# Altium schematic sheet Power_Supply.SchDoc
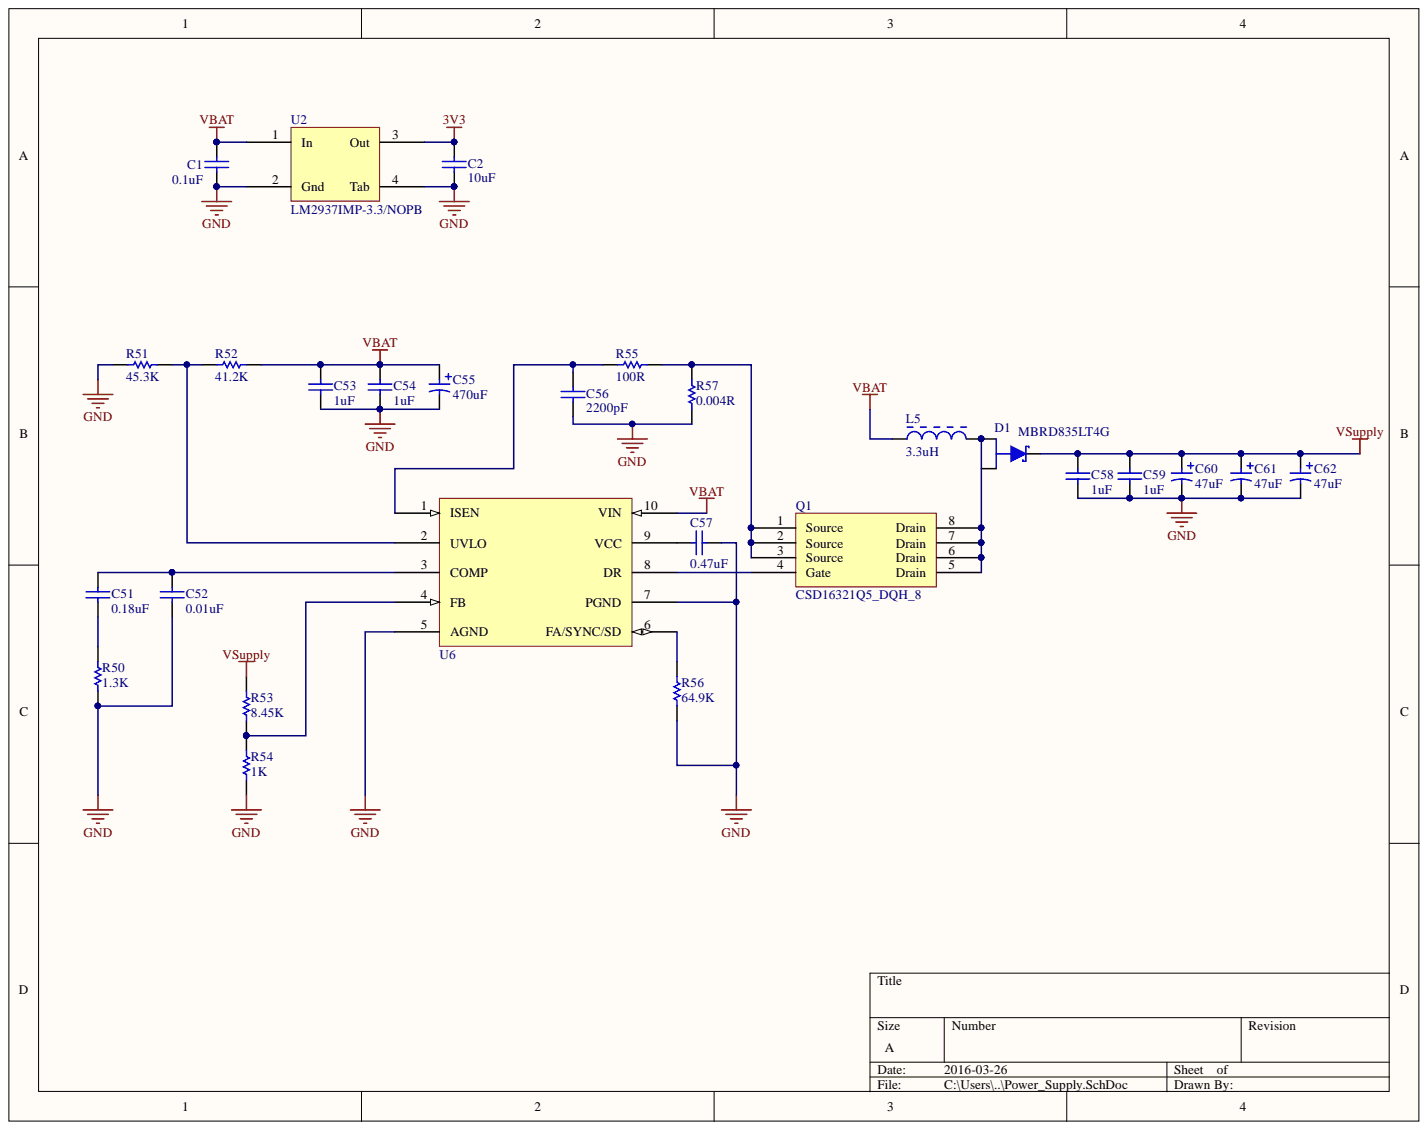
[diagram p0: Power_Supply.SchDoc]

## Parts
C1  0.1uF  [C1206]
C2  10uF  [C1206]
C51  0.18uF  [CAPC2012X09N]
C52  0.01uF  [CAPC2012X09N]
C53  1uF  [CAPC2012X09N]
C54  1uF  [CAPC2012X09N]
C55  470uF  [NICHICON 8x10 CAP]
C56  2200pF  [CAPC1608X09N]
C57  0.47uF  [CAPC1608X09N]
C58  1uF  [CAPC2012X09N]
C59  1uF  [CAPC2012X09N]
C60  47uF  [CAP_EEFK_D]
C61  47uF  [CAP_EEFK_D]
C62  47uF  [CAP_EEFK_D]
D1  MBRD835LT4G  [TO-252-3]
L5  3.3uH  [BOURNES SRP1770TA]
Q1  CSD16321Q5_DQH_8  [DQH8]
R50  1.3K  [0603]
R51  45.3K  [0603]
R52  41.2K  [0603]
R53  8.45K  [0603]
R54  1K  [0603]
R55  100R  [0603]
R56  64.9K  [0603]
R57  0.004R  [2512]
U2  LM2937IMP-3.3/NOPB  [DSO-G3/C6.4]
U6  LM3481  [VSSOP10]  (value not drawn)

## Nets
3V3: C2.2 U2.3(Out)
GND: C1.1 C2.1 C52.1 C53.1 C54.1 C55.2 C56.1 C57.2 C58.1 C59.1 C60.2 C61.2 C62.2 R50.1 R51.1 R54.1 R56.1 R57.1 U2.2(Gnd) U2.4(Tab) U6.5(AGND) U6.7(PGND)
VBAT: C1.2 C53.2 C54.2 C55.1 L5.1 R52.2 U2.1(In) U6.10(VIN)
VSUPPLY: C58.2 C59.2 C60.1 C61.1 C62.1 D1.4 R53.1
NetC51_1: C51.1 C52.2 U6.3(COMP)
NetC51_2: C51.2 R50.2
NetC56_2: C56.2 R55.1 U6.1(ISEN)
NetC57_1: C57.1 U6.9(VCC)
NetD1_1: D1.1 L5.2 Q1.5(Drain) Q1.6(Drain) Q1.7(Drain) Q1.8(Drain)
NetQ1_1: Q1.1(Source) Q1.2(Source) Q1.3(Source) R55.2 R57.2
NetQ1_4: Q1.4(Gate) U6.8(DR)
NetR51_2: R51.2 R52.1 U6.2(UVLO)
NetR53_2: R53.2 R54.2 U6.4(FB)
NetR56_2: R56.2 U6.6(FA/SYNC/SD)

Not connected: D1 3

## Library data of the parts
C1 = Cap Semi: Regulator Filter Cap; Component Kind: Standard; LatestRevisionDate: 29-May-2009; LatestRevisionNote: IPC-7351 Footprint Added.; Library Name: Miscellaneous Devices.IntLib; PackageDescription: Chip Capacitor; PackageReference: C1206; Published: 8-Jun-2000; Simulation: CAP; Value: 0.1uF; Publisher: Altium Limited
C2 = Cap Semi: 1206 Ceramic Capacitor; Component Kind: Standard; LatestRevisionDate: 29-May-2009; LatestRevisionNote: IPC-7351 Footprint Added.; Library Name: Miscellaneous Devices.IntLib; PackageDescription: Chip Capacitor; PackageReference: C1206; Published: 8-Jun-2000; Simulation: CAP; Value: 10uF; Publisher: Altium Limited
C51 = CAP CER 0.18uF 0805: Component Kind: Standard; Digikey Part Number: 399-3490-1-ND; Value: 0.18uF; Library Name: Speaker Library.SchLib
C52 = CAP CER 0.01uF 0805: Component Kind: Standard; Digikey Part Number: 490-1652-1-ND; Value: 0.01uF; Library Name: Speaker Library.SchLib
C53 C54 C58 C59 = CAP CER 1uF 0805: Voltage: 50V; Component Kind: Standard; Description: CAP CER 1uF 0805; Digikey Part Number: 587-2910-1-ND; Value: 1uF; Library Name: Speaker Library.SchLib
C55 = CAP ALUM 470uF SMD: Component Kind: Standard; Digikey Part Number: 493-2181-1-ND; Value: 470uF; Library Name: Speaker Library.SchLib
C56 = CAP CER 2200pF 0603: Component Kind: Standard; Digikey Part Number: 490-11512-1-ND; Value: 2200pF; Library Name: Speaker Library.SchLib
C57 = CAP CER 0.47uF 0603: Component Kind: Standard; Digikey Part Number: 490-3295-1-ND; Value: 0.47uF; Library Name: Speaker Library.SchLib
C60-C62 = CAP ALUM 47uF SMD: Component Kind: Standard; Digikey Part Number: PCE3842CT-ND; Value: 47uF; Library Name: Speaker Library.SchLib
D1 = MBRD835LT4G: SHOTTKEY 35V 8A; Configuration: Single; Type: SCHOT; Component Kind: Standard; Current: 8A; Digikey Part Number: MBRD835LT4GOSCT-ND; Voltage: 35V; Library Name: Speaker Library.SchLib
L5 = IND 3.3µH 28A SMD: Component Kind: Standard; Digikey Part Number: SRP1770TA-3R3MCT-ND; Value: 3.3uH; Library Name: Speaker Library.SchLib
Q1 = CSD16321Q5_DQH_8: Manufacturer: Texas Instruments; FAMILY_NAME: N-Channel MOSFET Transistors; INDUSTRY_STD_PKG_TYPE: SON; PACKAGE_DESIGNATOR: DQH; PIN_COUNT: 8; Type: DEV; Component Kind: Standard; Datasheet URL: http://www.ti.com/lit/gpn/CSD16321Q5; GENERIC_PART_NUMBER: CSD16321Q5; RefDes: RefDes; Value: Value; Library Name: Speaker Library.SchLib
R50 = RES 1.3K 0603: Manufacturer: Vishay Dale; Power: 0.1W; Tolerance: 1%; Component Kind: Standard; Digikey Part Number: 541-1.30KHCT-ND; Type: RES; Value: 1.3K; Library Name: Speaker Library.SchLib
R51 = RES 45.3K 0603: Manufacturer: Vishay Dale; Power: 0.1W; Tolerance: 1%; Component Kind: Standard; Digikey Part Number: 541-45.3KHCT-ND; Type: RES; Value: 45.3K; Library Name: Speaker Library.SchLib
R52 = RES 41.2K 0603: Manufacturer: Vishay Dale; Power: 0.1W; Tolerance: 1%; Component Kind: Standard; Digikey Part Number: 541-41.2KHCT-ND; Type: RES; Value: 41.2K; Library Name: Speaker Library.SchLib
R53 = RES 8.45K 0603: Manufacturer: Vishay Dale; Power: 0.1W; Tolerance: 1%; Component Kind: Standard; Digikey Part Number: 541-8.45KHCT-ND; Type: RES; Value: 8.45K; Library Name: Speaker Library.SchLib
R54 = RES 1K 0603: Manufacturer: Panasonic; Manufacturer Part Number: ERJ-PA3F1001V; Power: 0.25W; Tolerance: 1%; Component Kind: Standard; Digikey Part Number: P1.0KBYCT-ND; Temperature: 155dC; Type: RES; Value: 1K; Library Name: Speaker Library.SchLib
R55 = RES 100R 0603: Power: 0.25W; Tolerance: 1%; Component Kind: Standard; Digikey Part Number: P100BYCT-ND; Type: RES; Value: 100R; Library Name: Speaker Library.SchLib
R56 = RES 64.9K 0603: Manufacturer: Vishay Dale; Power: 0.1W; Tolerance: 1%; Component Kind: Standard; Digikey Part Number: 541-64.9KHCT-ND; Type: RES; Value: 64.9K; Library Name: Speaker Library.SchLib
R57 = RES 0.004R 2512: Manufacturer: Panasonic; Power: 1W; Tolerance: 1%; Component Kind: Standard; Digikey Part Number: P4.0MCT-ND; Type: RES; Value: 0.004R; Library Name: Speaker Library.SchLib
U6 = LM3481: IC REG CTRLR MULT TOP 10MSOP; Component Kind: Standard; Digikey Part Number: LM3481MM/NOPBCT-ND; Library Name: Speaker Library.SchLib
U2 = LM2937IMP-3.3/NOPB: Component Kind: Standard; Library Name: Speaker Library.SchLib
